# Altium schematic sheet Main_Shield.SchDoc
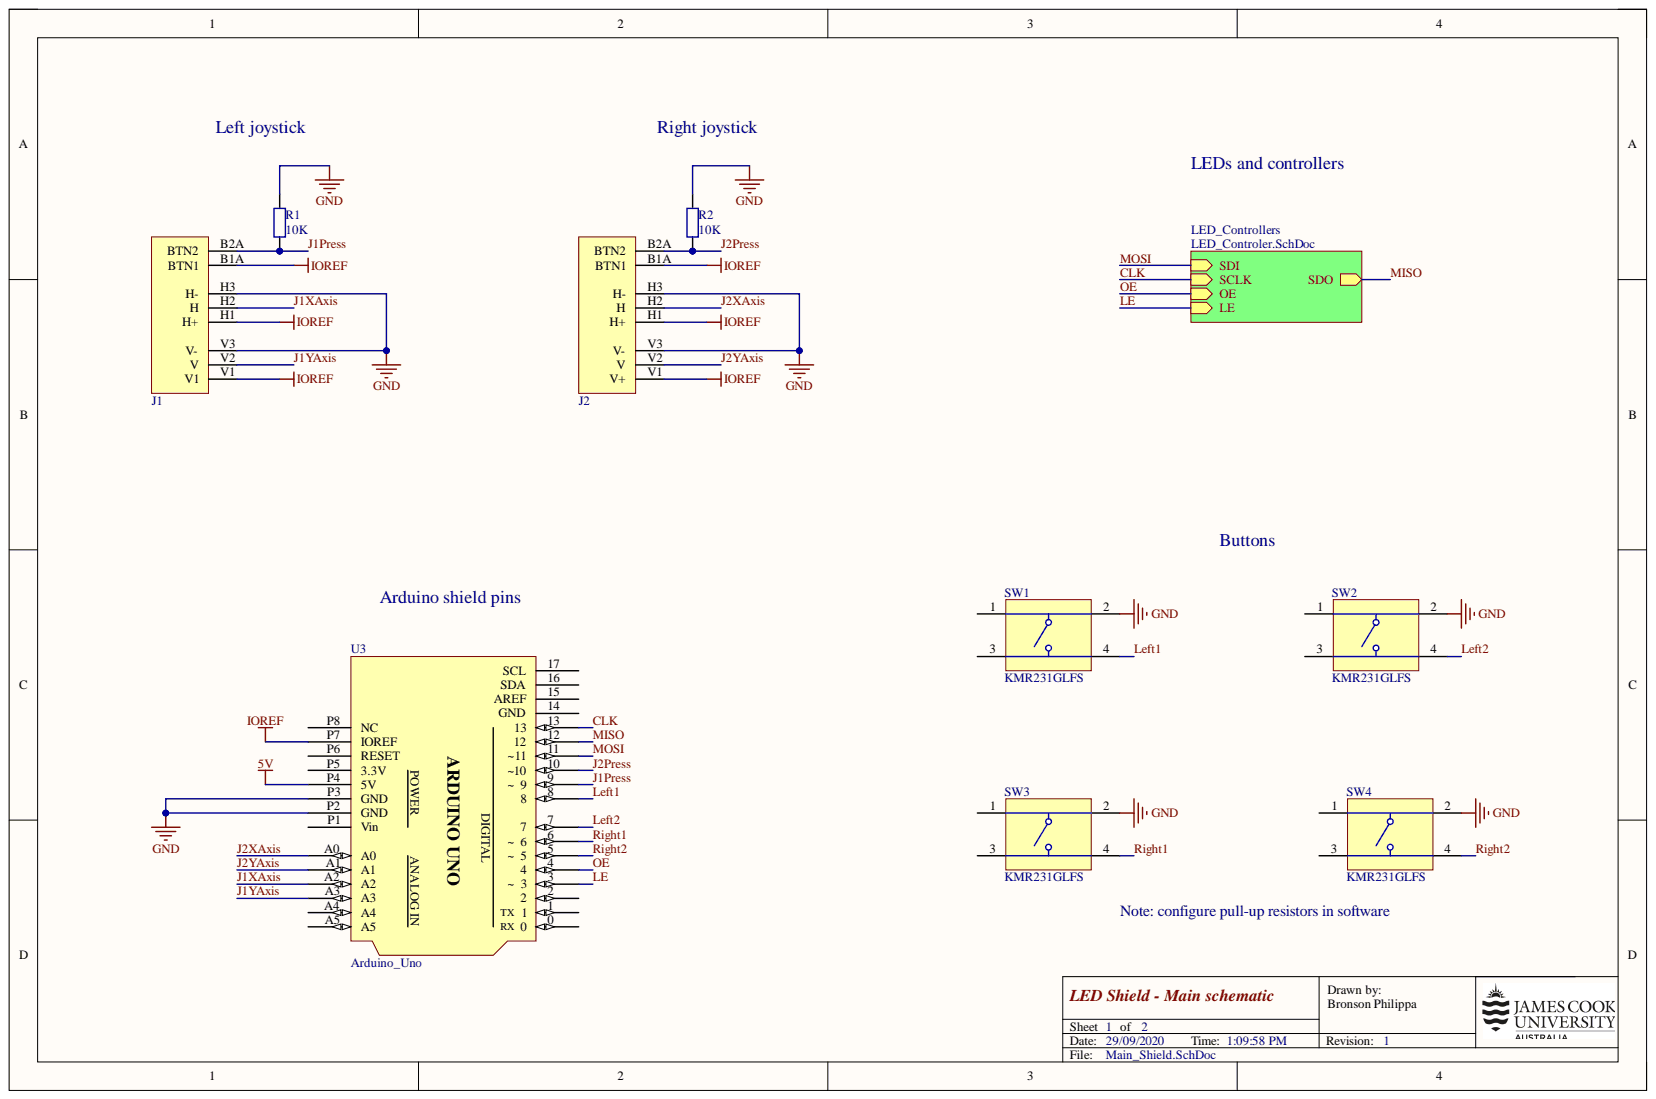
[diagram p0: Main_Shield.SchDoc]

## Parts
J1  Joystick  [JOYSTICK]  (value not drawn)
J2  Joystick  [JOYSTICK]  (value not drawn)
R1  value 10K  [AXIAL-0.4]  (hidden comment: Resistor Axial-0.4)
R2  value 10K  [AXIAL-0.4]  (hidden comment: Resistor Axial-0.4)
SW1  KMR231GLFS  [CKCP-KMR231GLFS]
SW2  KMR231GLFS  [CKCP-KMR231GLFS]
SW3  KMR231GLFS  [CKCP-KMR231GLFS]
SW4  KMR231GLFS  [CKCP-KMR231GLFS]
U3  Arduino_Uno  [ArduinoUnoR3]

## Nets
5V: U3.P4(5V)
GND: J1.H3(H-) J1.V3(V-) J2.H3(H-) J2.V3(V-) R1.1 R2.1 SW1.2 SW2.2 SW3.2 SW4.2 U3.P2(GND) U3.P3(GND)
IOREF: J1.B1A(BTN1) J1.H1(H+) J1.V1 J2.B1A(BTN1) J2.H1(H+) J2.V1(V+) U3.P7(IOREF)
CLK: U3.13
J1Press: J1.B2A(BTN2) R1.2 U3.9
J1XAxis: J1.H2(H) U3.A2
J1YAxis: J1.V2(V) U3.A3
J2Press: J2.B2A(BTN2) R2.2 U3.10(~_10)
J2XAxis: J2.H2(H) U3.A0
J2YAxis: J2.V2(V) U3.A1
LE: U3.3
Left1: SW1.4 U3.8
Left2: SW2.4 U3.7
MISO: U3.12
MOSI: U3.11(~_11)
OE: U3.4
Right1: SW3.4 U3.6
Right2: SW4.4 U3.5
NetSW1_1: SW1.1
NetSW1_3: SW1.3
NetSW2_1: SW2.1
NetSW2_3: SW2.3
NetSW3_1: SW3.1
NetSW3_3: SW3.3
NetSW4_1: SW4.1
NetSW4_3: SW4.3
NetU3_0: U3.0
NetU3_1: U3.1
NetU3_2: U3.2
NetU3_14: U3.14(GND)
NetU3_15: U3.15(AREF)
NetU3_16: U3.16(SDA)
NetU3_17: U3.17(SCL)
NetU3_A4: U3.A4
NetU3_A5: U3.A5
NetU3_P1: U3.P1(Vin)
NetU3_P5: U3.P5(3.3V)
NetU3_P6: U3.P6(RESET)
NetU3_P8: U3.P8(NC)

## Library data of the parts
J1 J2 = Joystick: Sparkfun Joystick COM-09032; Component Kind: Standard; Library Name: JCULibrary.SchLib
R1 R2 = Resistor Axial-0.4: Resistor; Component Kind: Standard; LatestRevisionDate: 17-Jul-2002; LatestRevisionNote: Re-released for DXP Platform.; PackageDescription: Axial Device, Thru-Hole; 2 Leads; 0.4 in Pin Spacing; PackageReference: AXIAL-0.4; Published: 8-Jun-2000; Signal Integrity: Res; Simulation: RESISTOR; Library Name: JCULibrary.SchLib; Publisher: Altium Limited
SW1-SW4 = CMP-2000-06516-1: Switch Tactile, SPST, 50 mA, 32 V, -40 to 85 degC, 4-Pin SMD, RoHS, Tape and Reel; Manufacturer: C&K Components; Actuator Length: 0.5mm; Actuator Style: Button; Body Orientation: Straight; Contact Rating @ Voltage: 1A; Dielectric Strength: >=250Vrms; Ground Terminal: Yes; Illumination Type: N/R; Maximum DC Voltage Rating: 32V; Mechanical Life: 150000Cycles; Minimum Insulation Resistance: >=1000MOhm; Operating Force: 3N; Switch Configuration: N.O.; Actuator Color: N/A; Base Material: N/A; Component Kind: Standard; Contact Material: Silver; Contact Plating: Silver; Datasheet URL: http://www.ck-components.com/media/1479/kmr2.pdf; Datasheet Version: 06/2016; Dimension: 2.8x4.6x1.9mm; ECCN: EAR99; Electrical Life: N/A; Family: KMR2; Housing Material: N/A; HTS: 8536501199; Library Name: Altium Content Vault; Manufacturer URL: http://www.ckswitches.com/; Maximum AC Voltage Rating: N/A; Mounting: SurfaceMount,GullWing; Mounting Technology: SurfaceMount; Operating Temperature: -40to85C; Package Description: 4-Pin Surface Mount Device, Body 4.6 x 2.8 mm; Package Reference: KMR231GLFS; Packaging: TapeandReel; Part No: KMR231GLFS; Pole Throw Configuration: SPST; Product Weight: N/A; RoHS: Yes; Seal Description: N/A; Seal Location: N/A; Supplier: C&KComponents; Type: Micro-Miniature
U3 = Arduino_Uno: Template for building an Arduino shield; Component Kind: Standard; Library Name: JCULibrary.SchLib
